# Altium schematic sheet STM32F103C8T6_V10.SchDoc(STM32F103C8T6_V10)
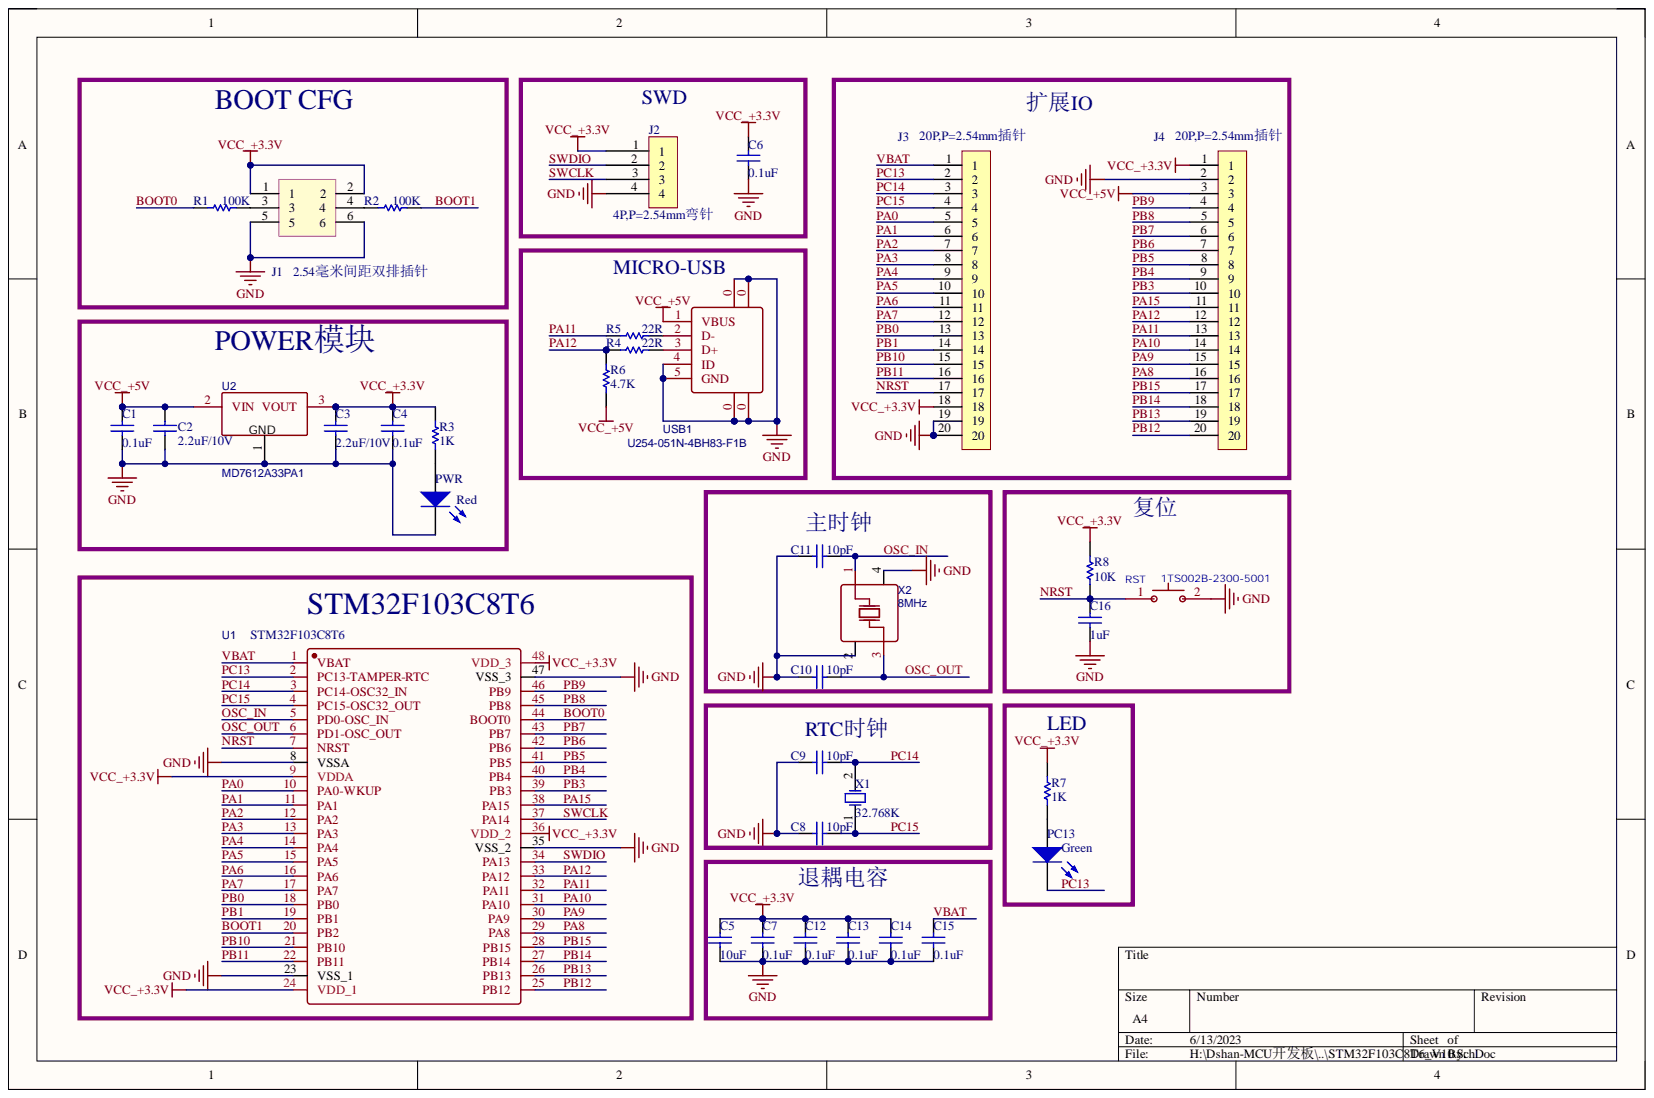
[diagram p0: STM32F103C8T6_V10.SchDoc]

## Parts
C1  0.1uF  [0603]
C2  2.2uF/10V  [0603]
C3  2.2uF/10V  [0603]
C4  0.1uF  [0603]
C5  10uF  [0603]
C6  0.1uF  [0603]
C7  0.1uF  [0603]
C8  10pF  [0603]
C9  10pF  [0603]
C10  10pF  [0603]
C11  10pF  [0603]
C12  0.1uF  [0603]
C13  0.1uF  [0603]
C14  0.1uF  [0603]
C15  0.1uF  [0603]
C16  1uF  [0603]
J1  2.54毫米间距双排插针  [IDC6]
J2  4P,P=2.54mm弯针
J3  20P,P=2.54mm插针
J4  20P,P=2.54mm插针
PC13  Green  [LED0603]
PWR  Red  [LED0603]
R1  100K  [0603]
R2  100K  [0603]
R3  1K  [0603]
R4  22R  [0603]
R5  22R  [0603]
R6  4.7K  [0603]
R7  1K  [0603]
R8  10K  [0603]
RST  1TS002B-2300-5001  [SW-SMD_L6.0-W3.5-LS8.0]
U1  STM32F103C8T6  [LQFP-48_L7.0-W7.0-P0.50-LS9.0-BL]
U2  MD7612A33PA1  [SOT-89-3_L4.5-W2.5-P1.50-LS4.2-BR]
USB1  U254-051N-4BH83-F1B  [MICRO-USB-SMD_U254-051N-4BH83-F1B]
X1  value 32.768K  [SMD3215]  (hidden comment: XTAL)
X2  8MHz  [OSC-SMD_3225_4P]

## Nets
GND: C1.2 C2.2 C3.2 C4.2 C5.2 C6.2 C7.2 C8.2 C9.2 C10.2 C11.2 C12.2 C13.2 C14.2 C15.2 C16.2 J1.5 J1.6 J2.4 J3.19 J3.20 J4.2 PWR.2 RST.2 U1.8(VSSA) U1.23(VSS_1) U1.35(VSS_2) U1.47(VSS_3) U2.1 USB1.0 USB1.4(ID) USB1.5(GND) X2.2 X2.4
VCC_+3.3V: C3.1 C4.1 C5.1 C6.1 C7.1 C12.1 C13.1 C14.1 J1.1 J1.2 J2.1 J3.18 J4.1 R3.1 R7.1 R8.2 U1.9(VDDA) U1.24(VDD_1) U1.36(VDD_2) U1.48(VDD_3) U2.3
VCC_+5V: C1.1 C2.1 J4.3 R6.1 U2.2 USB1.1(VBUS)
BOOT0: R1.2 U1.44(BOOT0)
BOOT1: R2.1 U1.20(PB2)
NRST: C16.1 J3.17 R8.1 RST.1 U1.7(NRST)
OSC_IN: C11.1 U1.5(PD0-OSC_IN) X2.1
OSC_OUT: C10.1 U1.6(PD1-OSC_OUT) X2.3
PA0: J3.5 U1.10(PA0-WKUP)
PA1: J3.6 U1.11(PA1)
PA2: J3.7 U1.12(PA2)
PA3: J3.8 U1.13(PA3)
PA4: J3.9 U1.14(PA4)
PA5: J3.10 U1.15(PA5)
PA6: J3.11 U1.16(PA6)
PA7: J3.12 U1.17(PA7)
PA8: J4.16 U1.29(PA8)
PA9: J4.15 U1.30(PA9)
PA10: J4.14 U1.31(PA10)
PA11: J4.13 R5.2 U1.32(PA11)
PA12: J4.12 R4.2 R6.2 U1.33(PA12)
PA15: J4.11 U1.38(PA15)
PB0: J3.13 U1.18(PB0)
PB1: J3.14 U1.19(PB1)
PB3: J4.10 U1.39(PB3)
PB4: J4.9 U1.40(PB4)
PB5: J4.8 U1.41(PB5)
PB6: J4.7 U1.42(PB6)
PB7: J4.6 U1.43(PB7)
PB8: J4.5 U1.45(PB8)
PB9: J4.4 U1.46(PB9)
PB10: J3.15 U1.21(PB10)
PB11: J3.16 U1.22(PB11)
PB12: J4.20 U1.25(PB12)
PB13: J4.19 U1.26(PB13)
PB14: J4.18 U1.27(PB14)
PB15: J4.17 U1.28(PB15)
PC13: J3.2 PC13.2 U1.2(PC13-TAMPER-RTC)
PC14: C9.1 J3.3 U1.3(PC14-OSC32_IN) X1.2
PC15: C8.1 J3.4 U1.4(PC15-OSC32_OUT) X1.1
SWCLK: J2.3 U1.37(PA14)
SWDIO: J2.2 U1.34(PA13)
VBAT: C15.1 J3.1 U1.1(VBAT)
NetJ1_3: J1.3 R1.1
NetJ1_4: J1.4 R2.2
NetPC13_1: PC13.1 R7.2
NetPWR_1: PWR.1 R3.2
NetR4_1: R4.1 USB1.3(D+)
NetR5_1: R5.1 USB1.2(D-)

## Library data of the parts
C1-C4 C6 C7 C12-C16 = Cap: Capacitor; PartNumber: CAP100NF; Component Kind: Standard; LatestRevisionDate: 17-Jul-2002; LatestRevisionNote: Re-released for DXP Platform.; Library Name: Miscellaneous Devices.IntLib; PackageReference: 0402; Published: 8-Jun-2000; Signal Integrity: Cap; Simulation: CAP; Publisher: Altium Limited
  C1 C4 C6 C7 C12-C15: Value: 0.1uF
  C2 C3: Value: 2.2uF/10V
  C16: Value: 1uF
C5 = Cap: Capacitor; PartNumber: CAP10UF; Component Kind: Standard; LatestRevisionDate: 17-Jul-2002; LatestRevisionNote: Re-released for DXP Platform.; Library Name: Miscellaneous Devices.IntLib; PackageReference: 0402; Published: 8-Jun-2000; Signal Integrity: Cap; Simulation: CAP; Value: 10uF; Publisher: Altium Limited
C8-C11 = Cap: Capacitor; PartNumber: CAP18PF; Component Kind: Standard; LatestRevisionDate: 17-Jul-2002; LatestRevisionNote: Re-released for DXP Platform.; Library Name: Miscellaneous Devices.IntLib; PackageReference: 0402; Published: 8-Jun-2000; Signal Integrity: Cap; Simulation: CAP; Value: 10pF; Publisher: Altium Limited
J1 = IDC6: Component Kind: Standard; Library Name: HM2.SchLib; Value: 2.54毫米间距双排插针
J2 = SIP4: Component Kind: Standard; Library Name: HM2.SchLib
J3 J4 = SIP20: Component Kind: Standard; Library Name: HM2.SchLib
PC13 PWR = LED2: Typical RED, GREEN, YELLOW, AMBER GaAs LED; Component Kind: Standard; LatestRevisionDate: 17-Jul-2002; LatestRevisionNote: Re-released for DXP Platform.; Library Name: Miscellaneous Devices.IntLib; PackageDescription: SM LED; 2 Flat Leads; PackageReference: 3.2X1.6X1.1; PackageVersion: Feb-2002; Published: 8-Jun-2000; Simulation: LED2; Publisher: Altium Limited
  PC13: Value: Green
  PWR: Value: Red
R1 R2 R4-R6 R8 = Res1: Resistor; PartNumber: RES100K; Component Kind: Standard; LatestRevisionDate: 17-Jul-2002; LatestRevisionNote: Re-released for DXP Platform.; Library Name: Miscellaneous Devices.IntLib; PackageReference: 0402; Published: 8-Jun-2000; Signal Integrity: Res; Simulation: RESISTOR; Publisher: Altium Limited
  R1 R2: Value: 100K
  R4 R5: Value: 22R
  R6: Value: 4.7K
  R8: Value: 10K
R3 R7 = Res1: Resistor; PartNumber: RES2.2K; Component Kind: Standard; LatestRevisionDate: 17-Jul-2002; LatestRevisionNote: Re-released for DXP Platform.; Library Name: Miscellaneous Devices.IntLib; PackageReference: 0402; Published: 8-Jun-2000; Signal Integrity: Res; Simulation: RESISTOR; Value: 1K; Publisher: Altium Limited
U1 = STM32F103C8T6: BOM_Manufacturer: STMicroelectronics; SMT Type: Basic; BOM_LCSC Assembly: Yes; BOM_Manufacturer Part: STM32F103C8T6; BOM_Supplier: LCSC; BOM_Supplier Part: C8734; Component Kind: Standard; image: //image.lceda.cn/szlcsc/C8734.jpg; spicePre: U; spiceSymbolName: STM32F103C8T6; timeStamp: 1455028086; Value: STM32F103C8T6
U2 = MD7612A33PA1: Contributor: lcsc; BOM_Manufacturer Part: MD7612A33PA1; BOM_Supplier: LCSC; BOM_Supplier Part: C2978350; Component Kind: Standard; Library Name: HM2.SchLib; link: https://atta.szlcsc.com/upload/public/pdf/source/20220309/DA883751BDA22ECB80106D5B2749DB60.pdf; spicePre: U; spiceSymbolName: MD7612A33PA1
USB1 = U254-051N-4BH83-F1B: BOM_Manufacturer: XKB Enterprise; Contributor: LCSC; BOM_JLCPCB Part Class: Extended Part; BOM_Manufacturer Part: U254-051N-4BH83-F1B; BOM_Supplier: LCSC; BOM_Supplier Part: C319169; Component Kind: Standard; Library Name: HM2.SchLib; spicePre: U; spiceSymbolName: U254-051N-4BH83-F1B
X1 = XTAL: Crystal Oscillator; Component Kind: Standard; LatestRevisionDate: 17-Jul-2002; LatestRevisionNote: Re-released for DXP Platform.; Library Name: Miscellaneous Devices.IntLib; PackageDescription: Crystal, Thru-Hole; 2 Leads; Body 3.1 x 8.2 mm (Dia.xH); PackageReference: R38; Published: 8-Jun-2000; Publisher: Altium Limited
X2 = 25MHz: BOM_Manufacturer: YXC; BOM_Manufacturer Part: XXDBELNAOF-24.000000MHZ; nameAlias: Frequency; SMT Type: Basic; BOM_LCSC Assembly: Yes; BOM_Supplier: LCSC; BOM_Supplier Part: C558785; Component Kind: Standard; spicePre: X; spiceSymbolName: XXDBELNAOF-24.000000MHZ
RST = 1TS002B-2300-5001: Component Kind: Standard
